# Altium schematic sheet KC4D_EXT_MOTOR_V1.2.SchDoc(KC4D_EXT_MOTOR_V1.2)
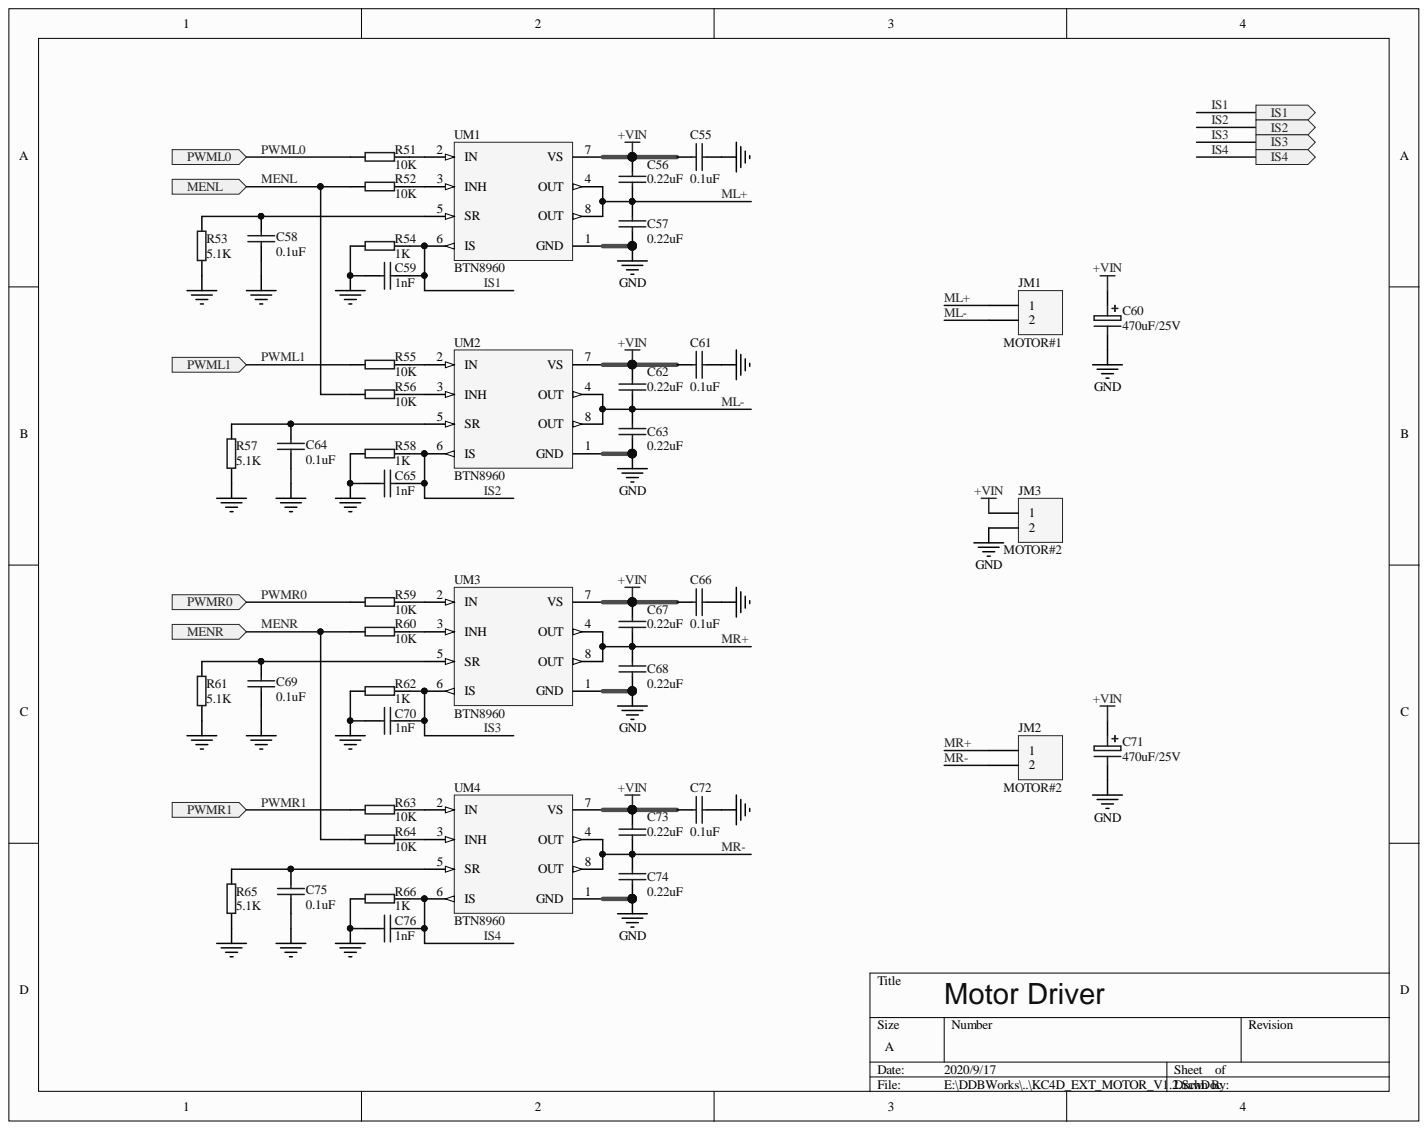
[diagram p0: KC4D_EXT_MOTOR_V1.2.SchDoc]

## Parts
C55  0.1uF  [C0603J]
C56  0.22uF  [C0603J]
C57  0.22uF  [C0603J]
C58  0.1uF  [C0603J]
C59  1nF  [C0603J]
C60  470uF/25V  [SMD-EC-F10]
C61  0.1uF  [C0603J]
C62  0.22uF  [C0603J]
C63  0.22uF  [C0603J]
C64  0.1uF  [C0603J]
C65  1nF  [C0603J]
C66  0.1uF  [C0603J]
C67  0.22uF  [C0603J]
C68  0.22uF  [C0603J]
C69  0.1uF  [C0603J]
C70  1nF  [C0603J]
C71  470uF/25V  [SMD-EC-F10]
C72  0.1uF  [C0603J]
C73  0.22uF  [C0603J]
C74  0.22uF  [C0603J]
C75  0.1uF  [C0603J]
C76  1nF  [C0603J]
JM1  MOTOR#1  [EDGRC-3.5-2P]
JM2  MOTOR#2  [EDGRC-3.5-2P]
JM3  MOTOR#2  [EDGRC-3.5-2P]
R51  10K  [R0603J]
R52  10K  [R0603J]
R53  5.1K  [R0603J]
R54  1K  [R0603J]
R55  10K  [R0603J]
R56  10K  [R0603J]
R57  5.1K  [R0603J]
R58  1K  [R0603J]
R59  10K  [R0603J]
R60  10K  [R0603J]
R61  5.1K  [R0603J]
R62  1K  [R0603J]
R63  10K  [R0603J]
R64  10K  [R0603J]
R65  5.1K  [R0603J]
R66  1K  [R0603J]
UM1  BTN8960  [BTS7960B]
UM2  BTN8960  [BTS7960B]
UM3  BTN8960  [BTS7960B]
UM4  BTN8960  [BTS7960B]

## Ports
IS1, IS2, IS3, IS4, MENL, MENR, PWML0, PWML1, PWMR0, PWMR1

## Nets
+VIN: C55.2 C56.1 C60.1 C61.2 C62.1 C66.2 C67.1 C71.1 C72.2 C73.1 JM3.1 UM1.7(VS) UM2.7(VS) UM3.7(VS) UM4.7(VS)
GND: C55.1 C57.2 C58.1 C59.1 C60.2 C61.1 C63.2 C64.1 C65.1 C66.1 C68.2 C69.1 C70.1 C71.2 C72.1 C74.2 C75.1 C76.1 JM3.2 R53.1 R54.1 R57.1 R58.1 R61.1 R62.1 R65.1 R66.1 UM1.1(GND) UM2.1(GND) UM3.1(GND) UM4.1(GND)
IS1: C59.2 R54.2 UM1.6(IS) ; port IS1
IS2: C65.2 R58.2 UM2.6(IS) ; port IS2
IS3: C70.2 R62.2 UM3.6(IS) ; port IS3
IS4: C76.2 R66.2 UM4.6(IS) ; port IS4
MENL: R52.1 R56.1 ; port MENL
MENR: R60.1 R64.1 ; port MENR
ML+: C56.2 C57.1 JM1.1 UM1.4(OUT) UM1.8(OUT)
ML-: C62.2 C63.1 JM1.2 UM2.4(OUT) UM2.8(OUT)
MR+: C67.2 C68.1 JM2.1 UM3.4(OUT) UM3.8(OUT)
MR-: C73.2 C74.1 JM2.2 UM4.4(OUT) UM4.8(OUT)
PWML0: R51.1 ; port PWML0
PWML1: R55.1 ; port PWML1
PWMR0: R59.1 ; port PWMR0
PWMR1: R63.1 ; port PWMR1
NetC58_2: C58.2 R53.2 UM1.5(SR)
NetC64_2: C64.2 R57.2 UM2.5(SR)
NetC69_2: C69.2 R61.2 UM3.5(SR)
NetC75_2: C75.2 R65.2 UM4.5(SR)
NetR51_2: R51.2 UM1.2(IN)
NetR52_2: R52.2 UM1.3(INH)
NetR55_2: R55.2 UM2.2(IN)
NetR56_2: R56.2 UM2.3(INH)
NetR59_2: R59.2 UM3.2(IN)
NetR60_2: R60.2 UM3.3(INH)
NetR63_2: R63.2 UM4.2(IN)
NetR64_2: R64.2 UM4.3(INH)

## Library data of the parts
C55 C58 C59 C61 C64-C66 C69 C70 C72 C75 C76 = CAP: PCB3D: 0805_0_0000_C; Part Field 4: 30pF; Part Field 3: Altium Limited; Part Field 2: July-2002: Re-released for DXP Platform.; Part Field 1: 8-Jun-2000; Component Kind: Standard
C56 C57 C62 C63 C67 C68 C73 C74 = CAP: Capacitor; PCB3D: 0805_0_0000_C; Component Kind: Standard
C60 C71 = CAPPOLSMD: Component Kind: Standard
JM1-JM3 = Header 2: Header, 2-Pin; Signal Integrity: Connector; Library Name: Miscellaneous Connectors.IntLib; LatestRevisionNote: Re-released for DXP Platform.; LatestRevisionDate: 17-Jul-2002; Component Kind: Standard; Publisher: Altium Limited
R51-R66 = Ra_DTE: PCB3D: 0805_0_0000_R; Library Name: DTE1.SchLib; Component Kind: Standard
UM1-UM4 = BTS7960B: Rule: Clearance Constraint [Clearance = 36mil]; Rule: Polygon Connect Style [Style - Direct Connect]; Library Name: DTE1.SchLib; Component Kind: Standard
